# Altium schematic sheet User_Interface.SchDoc("User Interface")
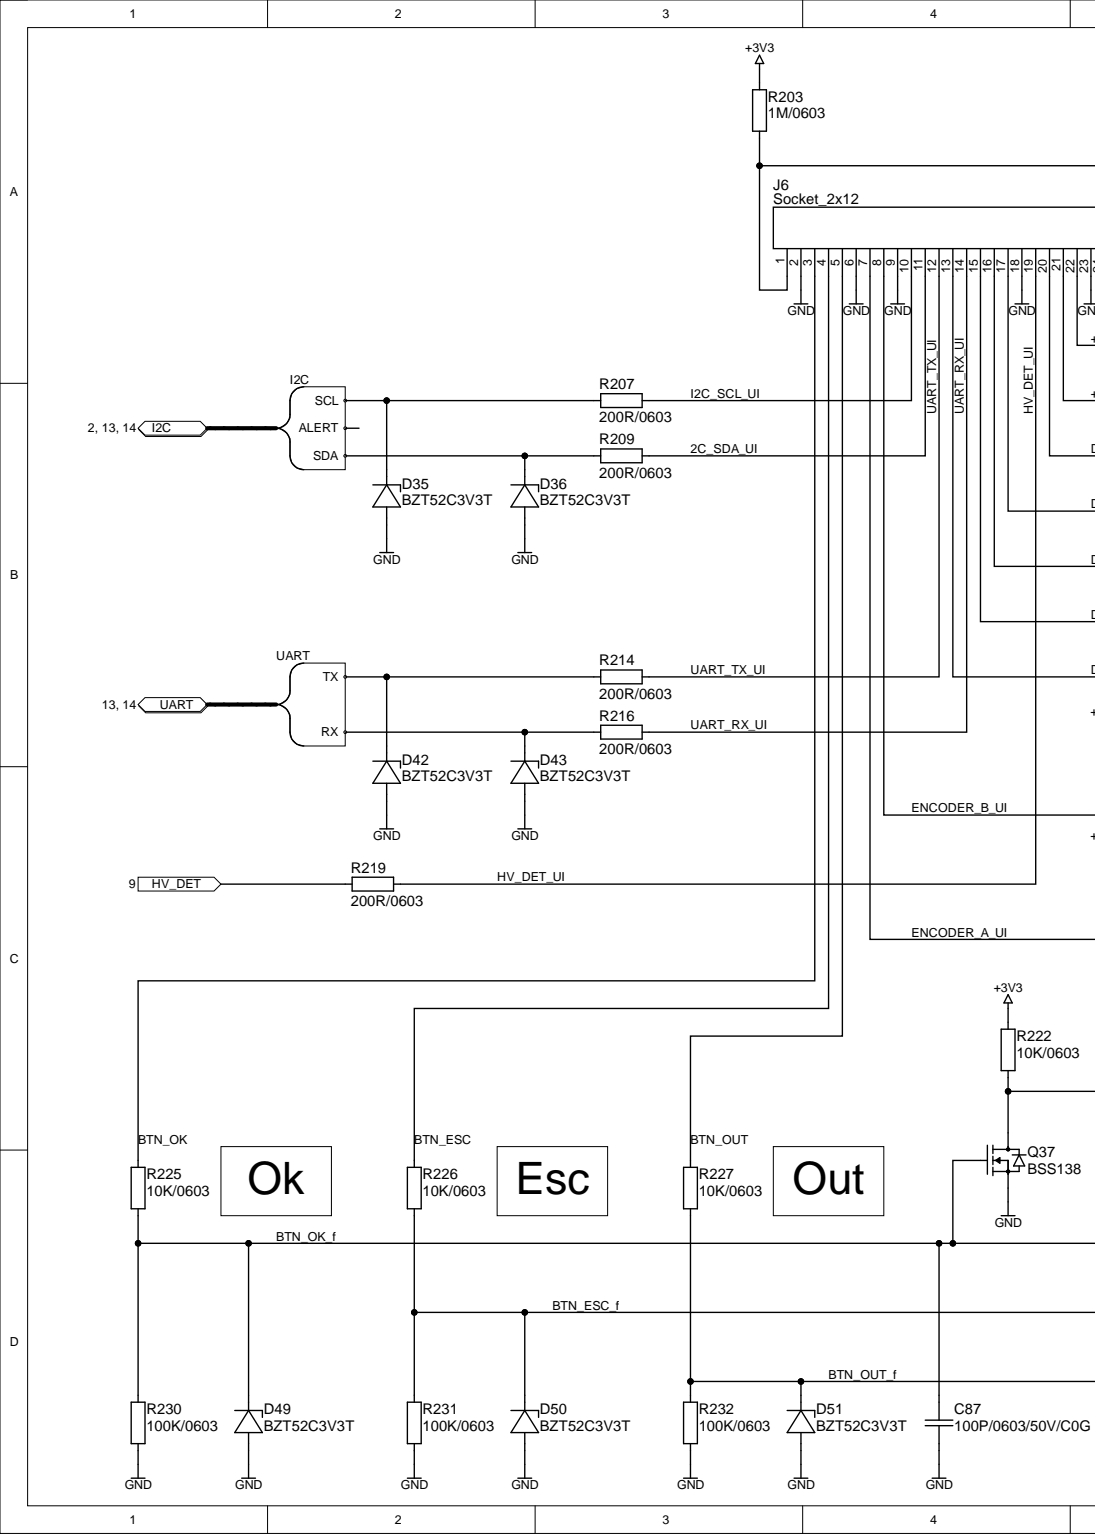
[diagram p0: User_Interface.SchDoc, left]
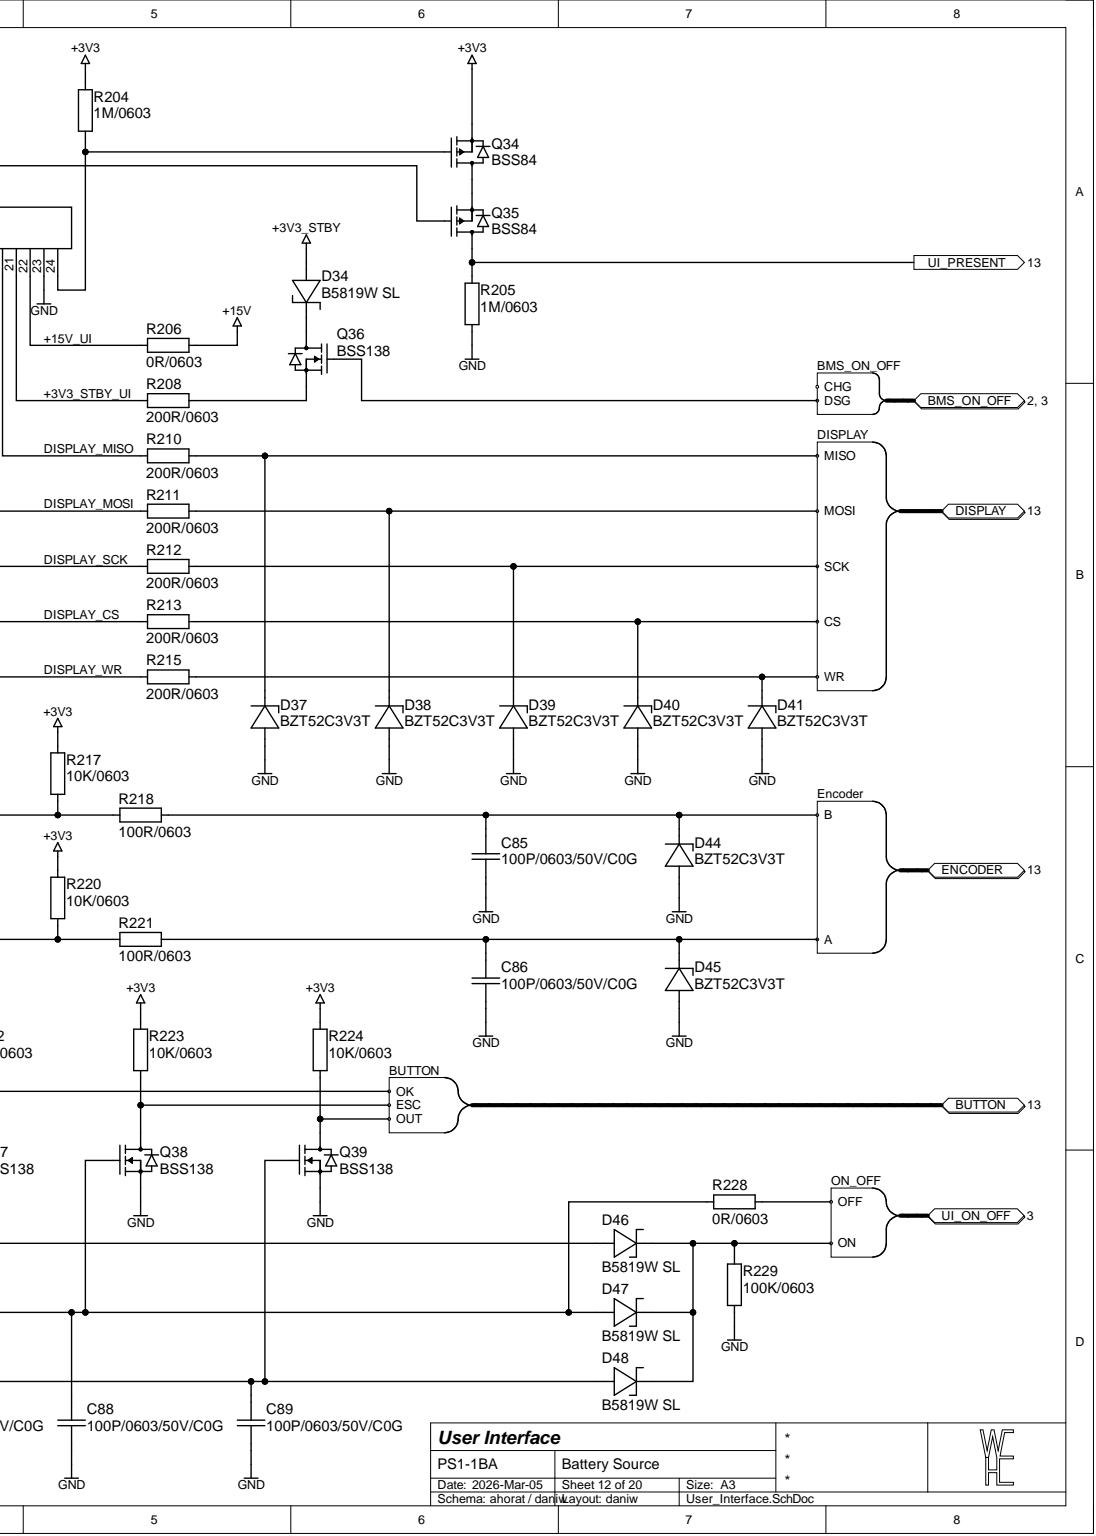
[diagram p1: User_Interface.SchDoc, right]

## Parts
C85  100P/0603/50V/C0G  [CAP0603_C0G]
C86  100P/0603/50V/C0G  [CAP0603_C0G]
C87  100P/0603/50V/C0G  [CAP0603_C0G]
C88  100P/0603/50V/C0G  [CAP0603_C0G]
C89  100P/0603/50V/C0G  [CAP0603_C0G]
D34  B5819W SL  [SOD3718X125]
D35  BZT52C3V3T  [SOD1608X065]
D36  BZT52C3V3T  [SOD1608X065]
D37  BZT52C3V3T  [SOD1608X065]
D38  BZT52C3V3T  [SOD1608X065]
D39  BZT52C3V3T  [SOD1608X065]
D40  BZT52C3V3T  [SOD1608X065]
D41  BZT52C3V3T  [SOD1608X065]
D42  BZT52C3V3T  [SOD1608X065]
D43  BZT52C3V3T  [SOD1608X065]
D44  BZT52C3V3T  [SOD1608X065]
D45  BZT52C3V3T  [SOD1608X065]
D46  B5819W SL  [SOD3718X125]
D47  B5819W SL  [SOD3718X125]
D48  B5819W SL  [SOD3718X125]
D49  BZT52C3V3T  [SOD1608X065]
D50  BZT52C3V3T  [SOD1608X065]
D51  BZT52C3V3T  [SOD1608X065]
J6  Socket_2x12  [SOCK_254_2X12_700]
Q34  BSS84  [SOT2395P280X125-3-SE]
Q35  BSS84  [SOT2395P280X125-3-SE]
Q36  BSS138  [SOT2395P280X125-3-SE]
Q37  BSS138  [SOT2395P280X125-3-SE]
Q38  BSS138  [SOT2395P280X125-3-SE]
Q39  BSS138  [SOT2395P280X125-3-SE]
R203  1M/0603  [R0603-0.40-WW]
R204  1M/0603  [R0603-0.40-WW]
R205  1M/0603  [R0603-0.40-WW]
R206  0R/0603  [R0603-0.40-WW]
R207  200R/0603  [R0603-0.40-WW]
R208  200R/0603  [R0603-0.40-WW]
R209  200R/0603  [R0603-0.40-WW]
R210  200R/0603  [R0603-0.40-WW]
R211  200R/0603  [R0603-0.40-WW]
R212  200R/0603  [R0603-0.40-WW]
R213  200R/0603  [R0603-0.40-WW]
R214  200R/0603  [R0603-0.40-WW]
R215  200R/0603  [R0603-0.40-WW]
R216  200R/0603  [R0603-0.40-WW]
R217  10K/0603  [R0603-0.40-WW]
R218  100R/0603  [R0603-0.40-WW]
R219  200R/0603  [R0603-0.40-WW]
R220  10K/0603  [R0603-0.40-WW]
R221  100R/0603  [R0603-0.40-WW]
R222  10K/0603  [R0603-0.40-WW]
R223  10K/0603  [R0603-0.40-WW]
R224  10K/0603  [R0603-0.40-WW]
R225  10K/0603  [R0603-0.40-WW]
R226  10K/0603  [R0603-0.40-WW]
R227  10K/0603  [R0603-0.40-WW]
R228  0R/0603  [R0603-0.40-WW]
R229  100K/0603  [R0603-0.40-WW]
R230  100K/0603  [R0603-0.40-WW]
R231  100K/0603  [R0603-0.40-WW]
R232  100K/0603  [R0603-0.40-WW]

## Ports
BMS_ON_OFF, BMS_ON_OFF.CHG, BMS_ON_OFF.DSG, BUTTON, BUTTON.ESC, BUTTON.OK, BUTTON.OUT, DISPLAY, DISPLAY.CS, DISPLAY.MISO, DISPLAY.MOSI, DISPLAY.SCK, DISPLAY.WR, ENCODER, ENCODER.A, ENCODER.B, HV_DET, I2C, I2C.ALERT, I2C.SCL, I2C.SDA, UART, UART.RX, UART.TX, UI_ON_OFF, UI_ON_OFF.OFF, UI_ON_OFF.ON, UI_PRESENT

## Nets
+3V3: Q34.2 R203.1 R204.1 R217.1 R220.1 R222.1 R223.1 R224.1
+3V3_STBY: D34.2
+15V: R206.2
GND: C85.2 C86.2 C87.2 C88.2 C89.2 D35.2 D36.2 D37.2 D38.2 D39.2 D40.2 D41.2 D42.2 D43.2 D44.2 D45.2 D49.2 D50.2 D51.2 J6.2 J6.6 J6.9 J6.18 J6.23 Q37.2 Q38.2 Q39.2 R205.2 R229.2 R230.2 R231.2 R232.2
2C_SDA_UI: J6.11 R209.2
+3V3_STBY_UI: J6.21 R208.2
+15V_UI: J6.22 R206.1
BMS_ON_OFF: Q36.1 ; port BMS_ON_OFF
BTN_ESC: J6.4 R226.1
BTN_ESC_f: C88.1 D47.2 D50.1 Q38.1 R226.2 R228.1 R231.1
BTN_OK: J6.3 R225.1
BTN_OK_f: C87.1 D46.2 D49.1 Q37.1 R225.2 R230.1
BTN_OUT: J6.5 R227.1
BTN_OUT_f: C89.1 D48.2 D51.1 Q39.1 R227.2 R232.1
DISPLAY_CS: J6.15 R213.1
DISPLAY_MISO: J6.20 R210.1
DISPLAY_MOSI: J6.17 R211.1
DISPLAY_SCK: J6.16 R212.1
DISPLAY_WR: J6.13 R215.1
ENCODER_A_UI: J6.7 R220.2 R221.1
ENCODER_B_UI: J6.8 R217.2 R218.1
HV_DET: R219.1 ; port HV_DET
HV_DET_UI: J6.19 R219.2
I2C_SCL_UI: J6.10 R207.2
UART_RX_UI: J6.14 R216.2
UART_TX_UI: J6.12 R214.2
UI_PRESENT: Q35.3 R205.1 ; port UI_PRESENT
NetC85_1: C85.1 D44.1 R218.2 ; port ENCODER
NetC86_1: C86.1 D45.1 R221.2 ; port ENCODER
NetD34_1: D34.1 Q36.3
NetD35_1: D35.1 R207.1 ; port I2C
NetD36_1: D36.1 R209.1 ; port I2C
NetD37_1: D37.1 R210.2 ; port DISPLAY
NetD38_1: D38.1 R211.2 ; port DISPLAY
NetD39_1: D39.1 R212.2 ; port DISPLAY
NetD40_1: D40.1 R213.2 ; port DISPLAY
NetD41_1: D41.1 R215.2 ; port DISPLAY
NetD42_1: D42.1 R214.1 ; port UART
NetD43_1: D43.1 R216.1 ; port UART
NetD46_1: D46.1 D47.1 D48.1 R229.1 ; port UI_ON_OFF
NetJ6_1: J6.1 Q35.1 R203.2
NetJ6_24: J6.24 Q34.1 R204.2
NetQ34_3: Q34.3 Q35.2
NetQ36_2: Q36.2 R208.1
NetQ37_3: Q37.3 R222.2 ; port BUTTON
NetQ38_3: Q38.3 R223.2 ; port BUTTON
NetQ39_3: Q39.3 R224.2 ; port BUTTON
NetR228_2: R228.2 ; port UI_ON_OFF
N00001: ; port I2C
N00002: ; port BMS_ON_OFF

## Library data of the parts
C85-C89 = 100P/0603/50V/C0G: CAP SM 0603 100pF 50V C0G; Manufacturer: GENERIC; Manufacturer 1: YAGEO; Manufacturer Part Number 1: CC0603JRNPO9BN101; Absolute Value: 1E-10; Database Table Name: C$; Eurocircuits GPN: GPC0603101-C0G; Component Kind: Standard; HelpURL: https://www.yageo.com/upload/media/product/productsearch/datasheet/mlcc/UPY-GP_NP0_16V-to-50V_18.pdf; JLC Type: Basic; Manufacturer 2: YAGEO; Manufacturer Part Number 2: CC0603KRNPO9BN101; Manufacturer Part Number 3: CL10C101JB8NNNC; Package: 0603; Part Number: 100P/0603/50V/C0G; Supplier 1: Digi-Key; Supplier 2: Digi-Key; Supplier 3: LCSC; Supplier Part Number 1: 311-1069-1-ND; Supplier Part Number 2: 311-3600-1-ND; Supplier Part Number 3: C14858; Value: 100pF; Library Name: daniw_altium_lib.DbLib
D34 D46-D48 = B5819W SL: 40V 600mV@1A 1A SOD-123 Schottky Barrier Diodes; Manufacturer: GENERIC; Manufacturer 1: SMC Diode Solutions; Database Table Name: D$; Absolute Value: 40V; Component Kind: Standard; HelpURL: https://datasheet.lcsc.com/lcsc/2304140030_Jiangsu-Changjing-Electronics-Technology-Co---Ltd--B5819W-SL_C8598.pdf; JLC Type: Basic; Manufacturer 3: Jiangsu Changjing Electronics Technology Co., Ltd.; Manufacturer Part Number 1: B5819WSL; Manufacturer Part Number 3: B5819W SL; Package: SOD-123; Part Number: B5819W SL; Supplier 1: Digikey; Supplier 3: LCSC; Supplier Part Number 1: 1655-B5819WSLCT-ND; Supplier Part Number 3: C8598; Value: B5819W SL; Library Name: daniw_altium_lib.DbLib
D35-D45 D49-D51 = BZT52C3V3T: DIODE ZENER 3.3V 300MW SOD523; Manufacturer: Diodes Incorporated; Manufacturer Part Number 1: BZT52C3V3T-7; Database Table Name: D$; Absolute Value: 3.3 V; Component Kind: Standard; HelpURL: https://www.diodes.com/assets/Datasheets/ds30502.pdf; Manufacturer 1: Diodes Incorporated; Manufacturer 2: Micro Commercial Co; Manufacturer 3: BORN; Manufacturer Part Number 2: BZT52C3V3T-TP; Manufacturer Part Number 3: BZT52C3V3T; Package: SC-79, SOD-523; Part Number: BZT52C3V3T; Supplier 1: Digikey; Supplier 2: Digikey; Supplier 3: LCSC; Supplier Part Number 1: BZT52C3V3T-7DICT-ND; Supplier Part Number 2: BZT52C3V3T-TPMSCT-ND; Supplier Part Number 3: C20612286; Value: BZT52C3V3T; Library Name: daniw_altium_lib.DbLib
J6 = Socket_2x12_7mm: Socket 2.100mil 2x12, height 7mm; Manufacturer: GENERIC; Manufacturer 1: Preci-Dip; Manufacturer Part Number 1: 803-87-024-10-001101; Database Table Name: CON$; System: Connector; Component Kind: Standard; Part Number: Socket_2x12_7mm; Supplier 1: Digi-Key; Supplier Part Number 1: 1212-1252-ND; Library Name: daniw_altium_lib.DbLib
Q34 Q35 = BSS84: 50V P-Channel MOSFET; Manufacturer: GENERIC; Manufacturer 1: Good-Ark Semiconductor; Database Table Name: T$; Component Kind: Standard; HelpURL: https://goodarksemi.com/docs/datasheets/mosfets/BSS84.pdf; JLC Type: Extended; Manufacturer 2: LRC; Manufacturer 3: Jiangsu Changjing Electronics Technology Co., Ltd.; Manufacturer Part Number 1: BSS84; Manufacturer Part Number 2: LBSS84LT1G; Manufacturer Part Number 3: BSS84; Package: SOT23; Part Number: BSS84; Supplier 1: Digi-Key; Supplier 2: LCSC; Supplier 3: LCSC; Supplier Part Number 1: 4786-BSS84CT-ND; Supplier Part Number 2: C8492; Supplier Part Number 3: C114481; Library Name: daniw_altium_lib.DbLib
Q36-Q39 = BSS138: N-Kanal 50 V 220mA (Ta) 350mW (Ta) Oberflächenmontage SOT-23; Manufacturer: GENERIC; Manufacturer 1: ANBON SEMICONDUCTOR (INT'L) LIMITED; Database Table Name: T$; Component Kind: Standard; HelpURL: https://mm.digikey.com/Volume0/opasdata/d220001/medias/docus/3037/BSS138.pdf; JLC Type: Extended; Manufacturer 2: FOSAN; Manufacturer 3: FOSAN; Manufacturer Part Number 1: BSS138; Manufacturer Part Number 2: BSS138; Manufacturer Part Number 3: BSS138; Package: SOT23; Part Number: BSS138; Supplier 1: Digi-Key; Supplier 2: LCSC; Supplier 3: LCSC; Supplier Part Number 1: 4530-BSS138CT-ND; Supplier Part Number 2: C2926186; Supplier Part Number 3: C2926186; Library Name: daniw_altium_lib.DbLib
R203-R205 = 1M/0603: RES SM 0603 1M; Manufacturer: GENERIC; Manufacturer 1: YAGEO; Manufacturer Part Number 1: RC0603FR-131ML; Absolute Value: 1000000; Database Table Name: R$; Eurocircuits GPN: GPR06031M; Component Kind: Standard; HelpURL: https://www.yageogroup.com/content/datasheet/asset/file/PYU-RC_GROUP_51_ROHS_L; JLC Type: Basic; Manufacturer 2: YAGEO; Manufacturer 3: UNI-ROYAL(Uniroyal Elec); Manufacturer Part Number 2: RC0603FR-101ML; Manufacturer Part Number 3: 0603WAF1004T5E; Package: 0603; Part Number: 1M/0603; Supplier 1: Digi-Key; Supplier 2: Digi-Key; Supplier 3: LCSC; Supplier Part Number 1: 13-RC0603FR-131MLCT-ND; Supplier Part Number 2: 13-RC0603FR-101MLCT-ND; Supplier Part Number 3: C22935; Value: 1M; Library Name: daniw_altium_lib.DbLib
R206 R228 = 0R/0603: RES SM 0603 0R; Manufacturer: GENERIC; Manufacturer 1: YAGEO; Manufacturer Part Number 1: RC0603FR-070RL; Absolute Value: 0; Database Table Name: R$; Eurocircuits GPN: GPR06030R; Component Kind: Standard; HelpURL: https://www.yageogroup.com/content/datasheet/asset/file/PYU-RC_GROUP_51_ROHS_L; JLC Type: Basic; Manufacturer 2: YAGEO; Manufacturer 3: UNI-ROYAL(Uniroyal Elec); Manufacturer Part Number 2: RC0603FR-130RL; Manufacturer Part Number 3: 0603WAF0000T5E; Package: 0603; Part Number: 0R/0603; Supplier 1: Digi-Key; Supplier 2: Digi-Key; Supplier 3: LCSC; Supplier Part Number 1: 311-0.0HRCT-ND; Supplier Part Number 2: 13-RC0603FR-130RLCT-ND; Supplier Part Number 3: C21189; Value: 0R; Library Name: daniw_altium_lib.DbLib
R207-R216 R219 = 200R/0603: RES SM 0603 200R; Manufacturer: GENERIC; Manufacturer 1: YAGEO; Manufacturer Part Number 1: RC0603FR-13200RL; Absolute Value: 200; Database Table Name: R$; Eurocircuits GPN: GPR0603200R; Component Kind: Standard; HelpURL: https://www.yageogroup.com/content/datasheet/asset/file/PYU-RC_GROUP_51_ROHS_L; JLC Type: Basic; Manufacturer 2: YAGEO; Manufacturer 3: UNI-ROYAL(Uniroyal Elec); Manufacturer Part Number 2: RC0603FR-07200RL; Manufacturer Part Number 3: 0603WAF2000T5E; Package: 0603; Part Number: 200R/0603; Supplier 1: Digi-Key; Supplier 2: Digi-Key; Supplier 3: LCSC; Supplier Part Number 1: 13-RC0603FR-13200RLCT-ND; Supplier Part Number 2: 311-200HRCT-ND; Supplier Part Number 3: C8218; Value: 200R; Library Name: daniw_altium_lib.DbLib
R217 R220 R222-R227 = 10K/0603: RES SM 0603 10K; Manufacturer: GENERIC; Manufacturer 1: YAGEO; Manufacturer Part Number 1: RC0603FR-1310KL; Absolute Value: 10000; Database Table Name: R$; Eurocircuits GPN: GPR060310K; Component Kind: Standard; HelpURL: https://www.yageogroup.com/content/datasheet/asset/file/PYU-RC_GROUP_51_ROHS_L; JLC Type: Basic; Manufacturer 2: YAGEO; Manufacturer 3: UNI-ROYAL(Uniroyal Elec); Manufacturer Part Number 2: RC0603FR-1010KL; Manufacturer Part Number 3: 0603WAF1002T5E; Package: 0603; Part Number: 10K/0603; Supplier 1: Digi-Key; Supplier 2: Digi-Key; Supplier 3: LCSC; Supplier Part Number 1: 13-RC0603FR-1310KLCT-ND; Supplier Part Number 2: 13-RC0603FR-1010KLCT-ND; Supplier Part Number 3: C25804; Value: 10K; Library Name: daniw_altium_lib.DbLib
R218 R221 = 100R/0603: RES SM 0603 100R; Manufacturer: GENERIC; Manufacturer 1: YAGEO; Manufacturer Part Number 1: RC0603FR-13100RL; Absolute Value: 100; Database Table Name: R$; Eurocircuits GPN: GPR0603100R; Component Kind: Standard; HelpURL: https://www.yageogroup.com/content/datasheet/asset/file/PYU-RC_GROUP_51_ROHS_L; Manufacturer 2: YAGEO; Manufacturer 3: UNI-ROYAL(Uniroyal Elec); Manufacturer Part Number 2: RC0603FR-10100RL; Manufacturer Part Number 3: 0603WAF1000T5E; Package: 0603; Part Number: 100R/0603; Supplier 1: Digi-Key; Supplier 2: Digi-Key; Supplier 3: LCSC; Supplier Part Number 1: 13-RC0603FR-13100RLCT-ND; Supplier Part Number 2: 13-RC0603FR-10100RLCT-ND; Supplier Part Number 3: C22775; Value: 100R; Library Name: daniw_altium_lib.DbLib
R229-R232 = 100K/0603: RES SM 0603 100K; Manufacturer: GENERIC; Manufacturer 1: YAGEO; Manufacturer Part Number 1: RC0603FR-13100KL; Absolute Value: 100000; Database Table Name: R$; Eurocircuits GPN: GPR0603100K; Component Kind: Standard; HelpURL: https://www.yageogroup.com/content/datasheet/asset/file/PYU-RC_GROUP_51_ROHS_L; JLC Type: Basic; Manufacturer 2: YAGEO; Manufacturer 3: UNI-ROYAL(Uniroyal Elec); Manufacturer Part Number 2: RC0603FR-10100KL; Manufacturer Part Number 3: 0603WAF1003T5E; Package: 0603; Part Number: 100K/0603; Supplier 1: Digi-Key; Supplier 2: Digi-Key; Supplier 3: LCSC; Supplier Part Number 1: 13-RC0603FR-13100KLCT-ND; Supplier Part Number 2: 13-RC0603FR-10100KLCT-ND; Supplier Part Number 3: C25803; Value: 100K; Library Name: daniw_altium_lib.DbLib
